# Altium schematic sheet Accelerometer.SchDoc(Accelerometer)
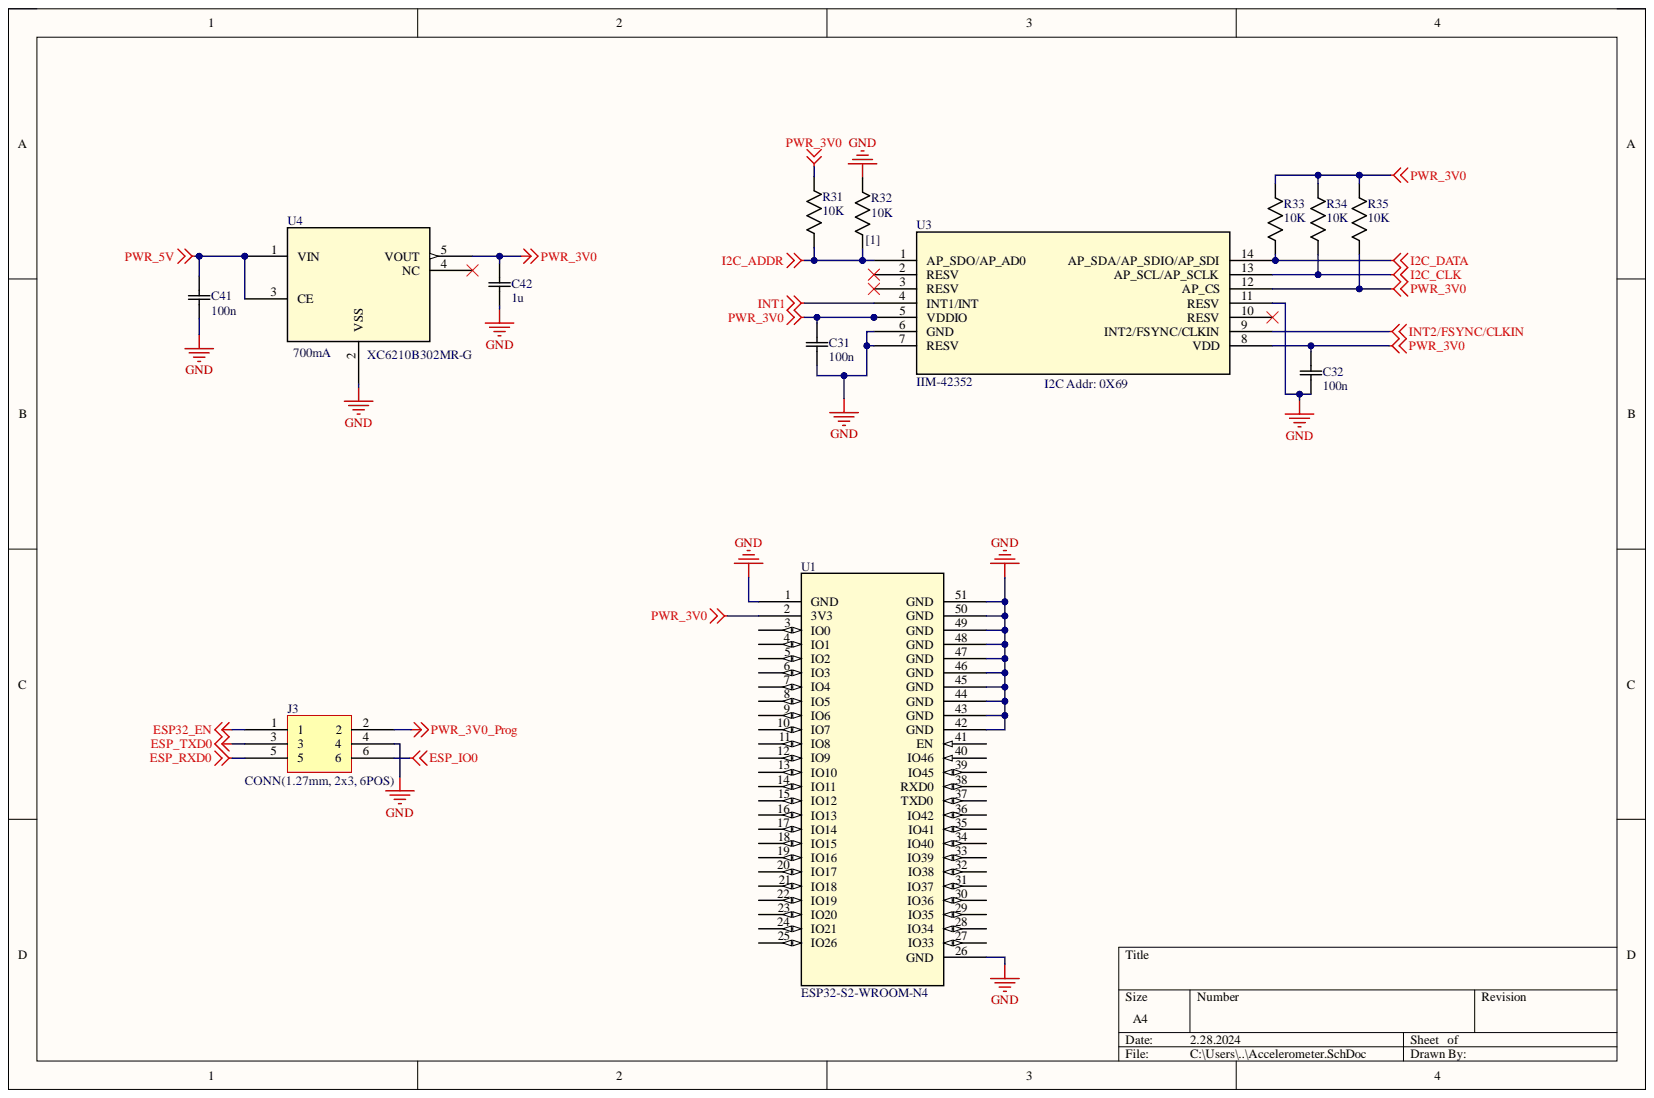
[diagram p0: Accelerometer.SchDoc]

## Parts
C31  100n  [YAG_CC0603_YAG]
C32  100n  [YAG_CC0603_YAG]
C41  100n  [YAG_CC0603_YAG]
C42  1u  [CAPC220145_100N_KEM]
J3  CONN(1.27mm, 2x3, 6POS)  [SAMTEC_SHF-103-01-L-D-SM]
R31  10K  [RC0603N_VIS]
R32  10K  [RC0603N_VIS]
R33  10K  [RC0603N_VIS]
R34  10K  [RC0603N_VIS]
R35  10K  [RC0603N_VIS]
U1  ESP32-S2-WROOM-N4  [ESP32-S2-WROOM_EXP]
U3  IIM-42352  [IIM-42652_TDK]
U4  XC6210B302MR-G  [SOT-25_TOR]

## Nets
ESP32_EN: J3.1
ESP_IO0: J3.6
ESP_RXD0: J3.5
ESP_TXD0: J3.3
GND: C31.1 C32.1 C41.1 C42.2 J3.4 R32.1 U1.1(GND) U1.26(GND) U1.42(GND) U1.43(GND) U1.44(GND) U1.45(GND) U1.46(GND) U1.47(GND) U1.48(GND) U1.49(GND) U1.50(GND) U1.51(GND) U3.6(GND) U3.7(RESV) U3.11(RESV) U4.2(VSS)
I2C_ADDR: R31.2 R32.2 U3.1(AP_SDO/AP_AD0)
I2C_CLK: R34.2 U3.13(AP_SCL/AP_SCLK)
I2C_DATA: R33.2 U3.14(AP_SDA/AP_SDIO/AP_SDI)
INT1: U3.4(INT1/INT)
INT2/FSYNC/CLKIN: U3.9(INT2/FSYNC/CLKIN)
PWR_3V0: C31.2 C32.2 C42.1 R31.1 R33.1 R34.1 R35.1 R35.2 U1.2(3V3) U3.5(VDDIO) U3.8(VDD) U3.12(AP_CS) U4.5(VOUT)
PWR_3V0_Prog: J3.2
PWR_5V: C41.2 U4.1(VIN) U4.3(CE)

Not connected: U1 3(IO0) 4(IO1) 5(IO2) 6(IO3) 7(IO4) 8(IO5) 9(IO6) 10(IO7) 11(IO8) 12(IO9) 13(IO10) 14(IO11) 15(IO12) 16(IO13) 17(IO14) 18(IO15) 19(IO16) 20(IO17) 21(IO18) 22(IO19) 23(IO20) 24(IO21) 25(IO26) 27(IO33) 28(IO34) 29(IO35) 30(IO36) 31(IO37) 32(IO38) 33(IO39) 34(IO40) 35(IO41) 36(IO42) 37(TXD0) 38(RXD0) 39(IO45) 40(IO46) 41(EN); U3 2(RESV) 3(RESV) 10(RESV); U4 4(NC)

## Library data of the parts
C31 C32 C41 = CC0603KRX7R8BB104: No Description Available; Mfr_Name: Yageo; Type: DEV; Component Kind: Standard; Library Name: CC0603KRX7R8BB104.IntLib; Manufacturer_Part_Number: CC0603KRX7R8BB104; RefDes: RefDes; Copyright: Copyright (C) 2024 Ultra Librarian. All rights reserved.
C42 = C0805C105Z4VACTU: No Description Available; Mfr_Name: Kemet; Type: DEV; Component Kind: Standard; Library Name: C0805C105Z4VACTU.IntLib; Manufacturer_Part_Number: C0805C105Z4VACTU; RefDes: RefDes; Copyright: Copyright (C) 2023 Ultra Librarian. All rights reserved.
J3 = SHF-103-01-L-D-SM: Connector Header Surface Mount 6 position 0.050 (1.27mm); MF: Samtec; Check_prices: https://www.snapeda.com/parts/SHF-103-01-L-D-SM/Samtec/view-part/3351308/?ref=eda; Component Kind: Standard; Digi-Key_Part_Number: SHF-103-01-L-D-SM-LC-ND; Library Name: CONN(1.27mm, 2x3, 6POS).IntLib; MP: SHF-103-01-L-D-SM; Package: None; Purchase-URL: https://pricing.snapeda.com/search/part/SHF-103-01-L-D-SM/?ref=eda; SnapEDA_Link: https://www.snapeda.com/parts/SHF-103-01-L-D-SM/Samtec/view-part/3351308/?ref=snap
R31-R35 = RES(10K, SMD-603): Mfr_Name: Vishay; Component Kind: Standard; Description: RES(10K, SMD-603); Library Name: CRCW060310K0JNEA.IntLib
U1 = ESP32-S2-WROOM-N4: No Description Available; Mfr_Name: Espressif Systems; Component Kind: Standard; Library Name: ESP32-S2-WROOM-N4.IntLib; RefDes: RefDes; Type: Type
U3 = IIM-42352: No Description Available; Mfr_Name: TDK; Component Kind: Standard; Library Name: IIM-42352.IntLib; RefDes: RefDes; Type: Type
U4 = XC6210B302MR-G: No Description Available; Mfr_Name: Torex Semiconductor; Component Kind: Standard; Library Name: XC6210B302MR-G.IntLib; RefDes: RefDes; Type: Type
